# Altium schematic sheet DriverAndProtection.SchDoc
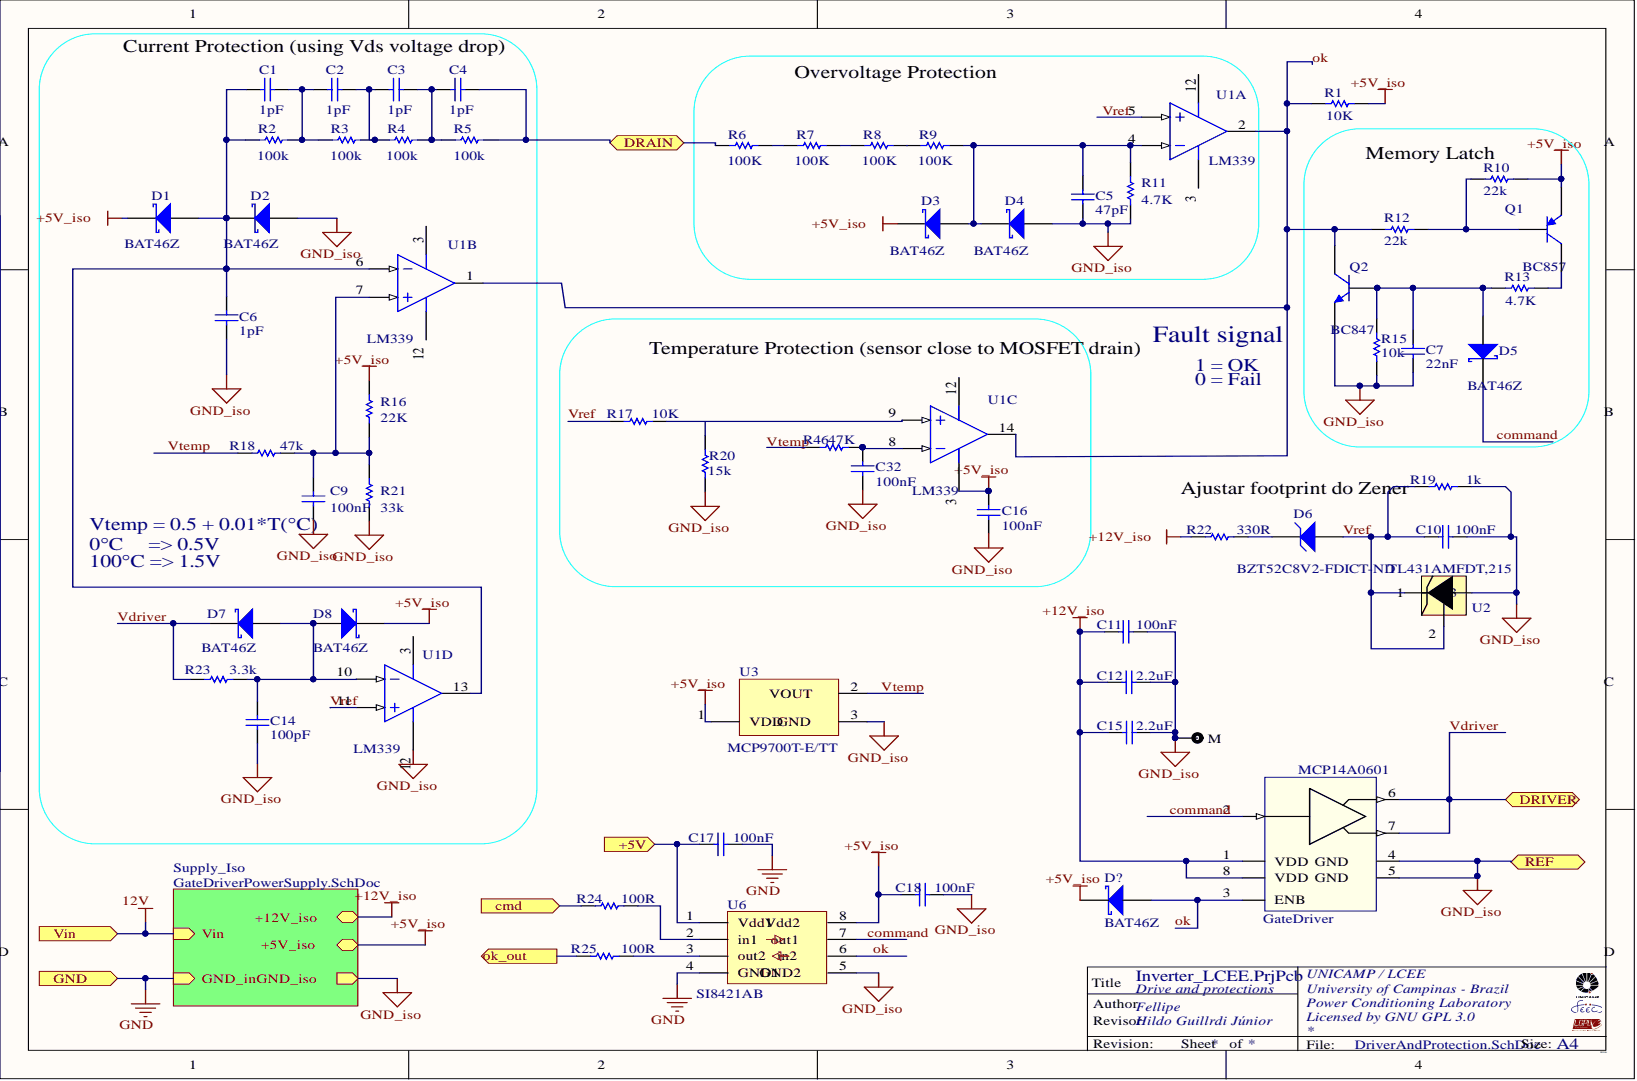
[diagram p0: DriverAndProtection.SchDoc]

## Parts
C1  value 1pF  [0805_W]
C2  value 1pF  [0805_W]
C3  value 1pF  [0805_W]
C4  value 1pF  [0805_W]
C5  value 47pF  [0805_W]
C6  value 1pF  [0805_W]
C7  value 22nF  [0805_W]
C9  value 100nF  [0805_W]
C10  value 100nF  [0805_W]
C11  value 100nF  [0805_W]
C12  value 2.2uF  [0805_W]
C14  value 100pF  [0805_W]
C15  value 2.2uF  [0805_W]
C16  value 100nF  [0805_W]
C17  value 100nF  [0805_W]
C18  value 100nF  [0805_W]
C32  value 100nF  [0805_W]
D1  value BAT46Z  [SOD-123]
D2  value BAT46Z  [SOD-123]
D3  value BAT46Z  [SOD-123]
D4  value BAT46Z  [SOD-123]
D5  value BAT46Z  [SOD-123]
D6  value BZT52C8V2-FDICT-ND  [SOD-123]
D7  value BAT46Z  [SOD-123]
D8  value BAT46Z  [SOD-123]
D?  value BAT46Z  [SOD-123]
GateDriver  value MCP14A0601  [SOIC-8N]
M1  Measure  (value not drawn)
Q1  value BC857  [SOT-23-TT3_N]
Q2  value BC847  [SOT-23-TT3_N]
R1  value 10K  [0805_W]
R2  value 100k  [0805_W]
R3  value 100k  [0805_W]
R4  value 100k  [0805_W]
R5  value 100k  [0805_W]
R6  value 100K  [0805_W]
R7  value 100K  [0805_W]
R8  value 100K  [0805_W]
R9  value 100K  [0805_W]
R10  value 22k  [0805_W]
R11  value 4.7K  [0805_W]
R12  value 22k  [0805_W]
R13  value 4.7K  [0805_W]
R15  value 10k  [0805_W]
R16  value 22K  [0805_W]
R17  value 10K  [0805_W]
R18  value 47k  [0805_W]
R19  value 1k  [0805_W]
R20  value 15k  [0805_W]
R21  value 33k  [0805_W]
R22  value 330R  [0805_W]
R23  value 3.3k  [0805_W]
R24  value 100R  [0805_W]
R25  value 100R  [0805_W]
R46  value 47K  [0805_W]
U1  value LM339  [SOIC-14N]  (parts A,B,C,D)
U2  value TL431AMFDT,215  [SOT-23-TT3_M]
U3  value MCP9700T-E/TT  [SOT-23_L]
U6  value SI8421AB  [SOIC-8N]

## Ports
+5V, CMD, DRAIN, DRIVER, GND, OK_OUT, REF, VIN

## Nets
+5V_ISO: C16.1 C18.2 D1.2 D3.2 D8.2 D?.2 Q1.2 R1.2 R10.1 R16.2 U1.3 U3.1 U6.8
+12V_ISO: C11.2 C12.1 C15.1 GateDriver.1(VDD) GateDriver.8(VDD) R22.1
+5V: C17.2 U6.1(Vdd1) ; port +5V
COMMAND: D5.2 GateDriver.2 U6.7
DRAIN: C4.1 R5.2 R6.2 ; port DRAIN
GND: C17.1 U6.4(GND1) ; port GND
GND_ISO: C5.2 C6.2 C7.2 C9.2 C10.2 C11.1 C12.2 C14.2 C15.2 C16.2 C18.1 C32.2 D2.1 D4.1 GateDriver.4 GateDriver.5 M1.0 Q2.2 R11.1 R15.2 R19.2 R20.2 R21.2 U1.12 U2.3 U3.3 U6.5 ; port REF
OK: D?.1 GateDriver.3(ENB) Q2.3 R1.1 R12.2 U1.1 U1.2 U1.14 U6.6
VDRIVER: D7.2 GateDriver.6 GateDriver.7 R23.2
VREF: C10.1 D6.1 R19.1 U1.5 U1.11 U2.1 U2.2
VTEMP: R18.2 U3.2
NetC1_1: C1.1 C2.2 R2.2 R3.2
NetC1_2: C1.2 C6.1 D1.1 D2.2 R2.1 U1.6 U1.13
NetC2_1: C2.1 C3.2 R3.1 R4.2
NetC3_1: C3.1 C4.2 R4.1 R5.1
NetC5_1: C5.1 D3.1 D4.2 R9.1 R11.2 U1.4
NetC7_1: C7.1 D5.1 Q2.1 R13.2 R15.1
NetC9_1: C9.1 R16.1 R18.1 R21.1 U1.7
NetC14_1: C14.1 D7.1 D8.1 R23.1 U1.10
NetC32_1: C32.1 R46.1 U1.8
NetD6_2: D6.2 R22.2
NetQ1_1: Q1.1 R10.2 R12.1
NetQ1_3: Q1.3 R13.1
NetR6_1: R6.1 R7.2
NetR7_1: R7.1 R8.2
NetR8_1: R8.1 R9.2
NetR17_1: R17.1 U1.9
NetR24_1: R24.1 U6.2(in1)
NetR24_2: R24.2 ; port CMD
NetR25_1: R25.1 U6.3(out2)
NetR25_2: R25.2 ; port OK_OUT
NetU1_3: U1.3
12V: ; port VIN
N00036:
N00037: ; port DRIVER

Not connected: R17 2; R20 1; R46 2

## Library data of the parts
C1-C7 C10 C11 C14 C16-C18 = Cap_0805: Capacitor; Component Kind: Standard; LatestRevisionDate: 17-Jul-2002; LatestRevisionNote: Re-released for DXP Platform.; PackageReference: RAD-0.3; Published: 8-Jun-2000; Signal Integrity: Cap; Simulation: CAP; Library Name: Inversor_LCEE.SchLib; Publisher: Altium Limited
C9 = Cap_0805: Capacitor; Value: 100nF; Component Kind: Standard; LatestRevisionDate: 17-Jul-2002; LatestRevisionNote: Re-released for DXP Platform.; PackageReference: RAD-0.3; Published: 8-Jun-2000; Signal Integrity: Cap; Simulation: CAP; Library Name: Inversor_LCEE.SchLib; Publisher: Altium Limited
C12 C15 = Capacitor_nao_polarizado: Capacitor nao polarizado; Component Kind: Standard; Library Name: Sch_LCEE.SchLib
C32 = Cap_0805: Capacitor; Value: 10; Component Kind: Standard; LatestRevisionDate: 17-Jul-2002; LatestRevisionNote: Re-released for DXP Platform.; PackageReference: RAD-0.3; Published: 8-Jun-2000; Signal Integrity: Cap; Simulation: CAP; Library Name: Inversor_LCEE.SchLib; Publisher: Altium Limited
D1-D4 D7 D8 = D Schottky: Schottky Diode; Code_JEDEC: DO-214-AA; Component Kind: Standard; LatestRevisionDate: 17-Jul-2002; LatestRevisionNote: Re-released for DXP Platform.; Library Name: Miscellaneous Devices.IntLib; PackageDescription: DO-214-AA/SMB; 2 C-Bend Leads; Body 5.3 x 3.6 mm, inc. leads (LxW); PackageReference: SMB; Published: 8-Jun-2000; Signal Integrity: D Schottky; Simulation: SKYDIODE; Publisher: Altium Limited
  D2-D4 D7 D8: BAT46Z: BAT46Z
D5 D? = Schottky: Diodo Schottky; Component Kind: Standard; Library Name: Sch_LCEE.SchLib
D6 = D Zener: Zener Diode; Value: BZT52C8V2-FDICT-ND; Component Kind: Standard; LatestRevisionDate: 17-Jul-2002; LatestRevisionNote: Re-released for DXP Platform.; Library Name: Miscellaneous Devices.IntLib; PackageDescription: Diode, Thru-Hole; 2 Leads; 0.7 in Pin Spacing; PackageReference: DIODE-0.7; Published: 8-Jun-2000; Signal Integrity: D Zener; Simulation: ZENER; Publisher: Altium Limited
GateDriver = GateDriver: Component Kind: Standard; Library Name: Inverter_LCEE.SchLib
Q1 = BC857: PNP General-purpose Transistor; Component Kind: Standard; ComponentLink1Description: Manufacturer Link; ComponentLink1URL: http://www.semiconductors.philips.com/; ComponentLink2Description: Datasheet; ComponentLink2URL: http://www.semiconductors.philips.com/acrobat/datasheets/BC856_BC857_BC858_6.pdf; DatasheetVersion: 4-Feb-2002; LatestRevisionDate: 12-Dec-2006; LatestRevisionNote: IPC-7351 Footprint Added.; Library Name: Philips Discrete BJT - Low Power.IntLib; PackageDescription: 3-Pin SOT-23 Package 0.95 mm Pitch, 2.3 mm Lead Span; PackageReference: SOT23; PackageVersion: May-1999; Published: 17-Sep-2003; Signal Integrity: BC857; Simulation: QBC857; Publisher: Altium Limited
Q2 = BC847: NPN General-purpose Transistor; Component Kind: Standard; ComponentLink1Description: Manufacturer Link; ComponentLink1URL: http://www.semiconductors.philips.com/; ComponentLink2Description: Datasheet; ComponentLink2URL: http://www.semiconductors.philips.com/acrobat/datasheets/BC846_BC847_BC848_5.pdf; DatasheetVersion: 4-Feb-2002; LatestRevisionDate: 12-Dec-2006; LatestRevisionNote: IPC-7351 Footprint Added.; Library Name: Philips Discrete BJT - Low Power.IntLib; PackageDescription: 3-Pin SOT-23 Package 0.95 mm Pitch, 2.3 mm Lead Span; PackageReference: SOT23; PackageVersion: May-1999; Published: 17-Sep-2003; Signal Integrity: BC847; Simulation: QBC847; Publisher: Altium Limited
R1-R9 R11-R13 R16 R17 R21 R23-R25 R46 = Res_0805: Resistor; Manufacturer: Generic Components; Class: Passive; Component Kind: Standard; Published: 08/06/2000; Signal Integrity: Res1; Simulation: RESISTOR; SubClass: Resistor; Library Name: Inversor_LCEE.SchLib; Publisher: Altium Hobart Technology Centre
R10 R15 R19 R22 = Res_0805: Resistor- Not Used; Manufacturer: Generic Components; Class: Passive; Component Kind: Standard; Published: 08/06/2000; Signal Integrity: Res1; Simulation: RESISTOR; SubClass: Resistor; Library Name: Inversor_LCEE.SchLib; Publisher: Altium Hobart Technology Centre
R18 R20 = Res_0805: Resistor; Manufacturer: Generic Components; Class: Passive; Value: 10; Component Kind: Standard; Published: 08/06/2000; Signal Integrity: Res1; Simulation: RESISTOR; SubClass: Resistor; Library Name: Inversor_LCEE.SchLib; Publisher: Altium Hobart Technology Centre
U1 = LM339AD: Low-Power Quad Voltage Comparator; Code_IPC: SOIC127P600-14; Component Kind: Standard; ComponentLink1Description: Manufacturer Link; ComponentLink1URL: http://www.st.com/; ComponentLink2Description: Datasheet; ComponentLink2URL: http://www.st.com/stonline/products/literature/ds/2159/lm239.pdf; DatasheetVersion: Jun-1998; LatestRevisionDate: 13-Apr-2006; LatestRevisionNote: IPC-7351 Footprint Added.; Library Name: ST Analog Comparator.IntLib; PackageDescription: 14-Pin Small Outline Integrated Circuit 1.27 mm Pitch; PackageReference: SO14; PackageVersion: Sep-1998; PCB3D: SO14; Published: 24-Aug-2001; Publisher: Altium Limited
U2 = TL431ACLP: Component Kind: Standard; Library Name: Inversor_LCEE.SchLib
U3 = MCP9700T-E/TT: Low-Power Linear Active Thermistor IC, 3-Pin SOT-23, Extended Temperature, Tape and Reel; Code_IPC: SOT23-3; Component Kind: Standard; ComponentLink1Description: Datasheet; ComponentLink1URL: http://ww1.microchip.com/downloads/en/DeviceDoc/21942e.pdf; ComponentLink2Description: Packaging Specification; ComponentLink2URL: http://www.microchip.com/stellent/groups/techpub_sg/documents/packagingspec/en012702.pdf; ComponentLink3Description: Microchip.com Home; ComponentLink3URL: http://www.microchip.com/; DatasheetVersion: revE, Apr-2009; LatestRevisionDate: 02-Dec-2009; LatestRevisionNote: Updated datasheet to version revE, Apr-2009.; Library Name: Microchip Thermal Management.IntLib; PackageDescription: 3-Lead Plastic Small Outline Transistor (TT) [SOT-23]; PackageReference: SOT-23-TT3; PackageVersion: revBB, Aug-2009; Published: 29-Jan-2009; Supplier 1: Digi-Key; Supplier 2: Digi-Key; Supplier 3: Digi-Key; Supplier 4: Farnell; Supplier Part Number 1: MCP9700T-E/TTTR-ND; Supplier Part Number 2: MCP9700T-E/TTCT-ND; Supplier Part Number 3: MCP9700T-E/TTDKR-ND; Supplier Part Number 4: 1627188; Publisher: Altium Limited
U6 = Si8421: Two Channel, High Speed Digital Isolators; Component Kind: Standard; Library Name: Inverter_LCEE.SchLib
M1 = Measure: Component Kind: Standard; Library Name: Inverter_LCEE.SchLib
